# Altium schematic sheet CAN_RPI_Supply.SchDoc
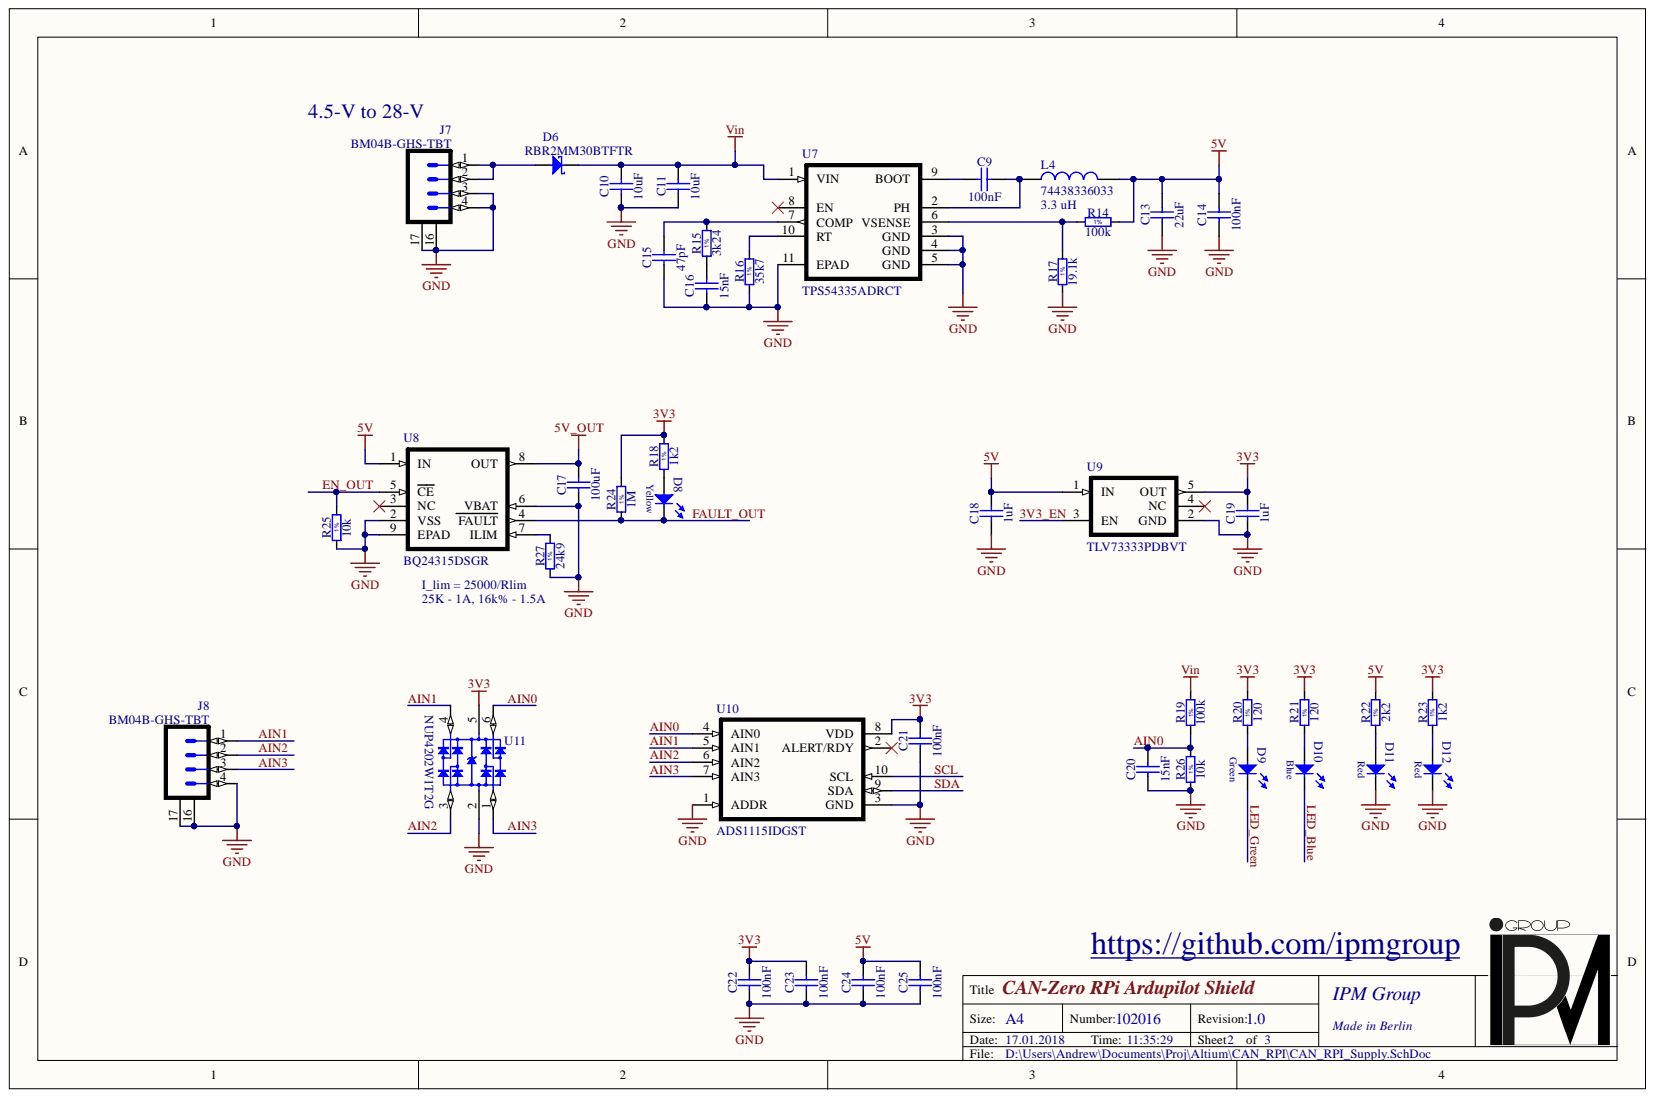
[diagram p0: CAN_RPI_Supply.SchDoc]

## Parts
C9  100nF  [CAPC1005X55N]
C10  10uF  [CAPC3216X190N]
C11  10uF  [CAPC3216X190N]
C13  22uF  [CAPC2012X135N]
C14  100nF  [CAPC1005X55N]
C15  47pF  [CAPC1005X55N]
C16  15nF  [CAPC1005X55N]
C17  100uF  [CAPC3216X180N]
C18  1uF  [CAPC1005X55N]
C19  1uF  [CAPC1005X55N]
C20  15nF  [CAPC1005X55N]
C21  100nF  [CAPC1005X55N]
C22  100nF  [CAPC1005X55N]
C23  100nF  [CAPC1005X55N]
C24  100nF  [CAPC1005X55N]
C25  100nF  [CAPC1005X55N]
D6  RBR2MM30BTFTR  [SOD-123FL]
D8  Color: Yellow  [LEDC1608X80N_RED]  (hidden comment: KPT-1608YC)
D9  KP-1608SGC  [LEDC1608X80N_GREEN]  (value not drawn)
D10  Color: Blue  [LEDC1608X80N_RED]  (hidden comment: KPT-1608QBC-D)
D11  Color: Red  [LEDC1608X80N_RED]  (hidden comment: KP-1608EC)
D12  Color: Red  [LEDC1608X80N_RED]  (hidden comment: KP-1608EC)
J7  BM04B-GHS-TBT  [JST_BM04B]
J8  BM04B-GHS-TBT  [JST_BM04B]
L4  74438336033  [WE-MAPI_3020]
R14  100k  [RESC1005X40N]
R15  3k24  [RESC1005X40N]
R16  35k7  [RESC1005X40N]
R17  19.1k  [RESC1005X40N]
R18  1k2  [RESC1005X40N]
R19  100k  [RESC1005X40N]
R20  120  [RESC1005X40N]
R21  120  [RESC1005X40N]
R22  2k2  [RESC1005X40N]
R23  1k2  [RESC1005X40N]
R24  1M  [RESC1005X40N]
R25  10k  [RESC1005X40N]
R26  10k  [RESC1005X40N]
R27  24k9  [RESC1005X40N]
U7  TPS54335ADRCT  [DRC0010J]
U8  BQ24315DSGR  [DSG8_P9X1P6]
U9  TLV73333PDBVT  [DBV5A]
U10  ADS1115IDGST  [DGS10]
U11  NUP4202W1T2G  [SOIC127P600X175-8N]

## Nets
3V3: C19.2 C21.2 C22.2 C23.2 R18.2 R20.2 R21.2 R23.2 R24.2 U9.5(OUT) U10.8(VDD) U11.5
5V: C13.2 C14.2 C18.2 C24.2 C25.2 L4.2 R14.2 R22.2 U8.1(IN) U9.1(IN)
5V_OUT: C17.2 U8.8(OUT)
GND: C10.1 C11.1 C13.1 C14.1 C15.1 C16.1 C17.1 C18.1 C19.1 C20.1 C21.1 C22.1 C23.1 C24.1 C25.1 D11.1 D12.1 J7.3 J7.4 J7.16 J7.17 J8.4 J8.16 J8.17 R16.1 R17.1 R25.1 R26.1 R27.1 U7.3(GND) U7.4(GND) U7.5(GND) U7.11(EPAD) U8.2(VSS) U8.6(VBAT) U8.9(EPAD) U9.2(GND) U10.1(ADDR) U10.3(GND) U11.2
Vin: C10.2 C11.2 D6.1 R19.2 U7.1(VIN)
3V3_EN: U9.3(EN)
AIN0: C20.2 R19.1 R26.2 U10.4(AIN0) U11.6
AIN1: J8.1 U10.5(AIN1) U11.4
AIN2: J8.2 U10.6(AIN2) U11.3
AIN3: J8.3 U10.7(AIN3) U11.1
EN_OUT: R25.2 U8.5(CE)
FAULT_OUT: D8.1 R24.1 U8.4(FAULT)
LED_Blue: D10.1
LED_Green: D9.1
SCL: U10.10(SCL)
SDA: U10.9(SDA)
NetC9_1: C9.1 U7.9(BOOT)
NetC9_2: C9.2 L4.1 U7.2(PH)
NetC15_2: C15.2 R15.2 U7.7(COMP)
NetC16_2: C16.2 R15.1
NetD6_2: D6.2 J7.1 J7.2
NetD8_2: D8.2 R18.1
NetD9_2: D9.2 R20.1
NetD10_2: D10.2 R21.1
NetD11_2: D11.2 R22.1
NetD12_2: D12.2 R23.1
NetR14_1: R14.1 R17.2 U7.6(VSENSE)
NetR16_2: R16.2 U7.10(RT)
NetR27_2: R27.2 U8.7(ILIM)

Not connected: U7 8(EN); U8 3(NC); U9 4(NC); U10 2(ALERT/RDY)

## Library data of the parts
C9 = CC0402_100NF_50V_10%_X7R: Non-polarised capacitor; Manufacturer: GENERIC; Manufacturer1 Part Number: C1005X7R1H104M050BB; Part Description: SMD Multilayer Chip Ceramic Capacitor; Database Table Name: Capacitors SMD; TC: X7R; Tolerance: ±10%; Voltage: 50V; Case: 0402; Component Kind: Standard; Component Type: Standard; Library Name: Capacitors.DbLib; Manufacturer1 ComponentHeight: 0.55mm; Manufacturer1 Example: TDK; Manufacturer Part Number: CC0402_100NF_50V_10%_X7R; Mounted: Yes; Part Number: CC0402_100NF_50V_10%_X7R; Pin Count: 2; SMD: Yes; Supplier 1: Farnell; Supplier 2: RSComponents; Supplier 3: Digi-Key; Supplier Part Number 1: 2611878; Value: 100nF; Author: CERN DEM JLC
C10 C11 = CC1206_10UF_50V_10%_X5R_TDK_CGA5L3X5R1H106K160AB: Non-polarised capacitor; Manufacturer: MURATA; Manufacturer1 Part Number: GRM31CR6YA106KA12L; Manufacturer Part Number: CC1206_10UF_35V_10%_X5R; Part Description: SMD Multilayer Chip Ceramic Capacitor (Automotive Grade); Database Table Name: Capacitors SMD; TC: X5R; Tolerance: ±10%; Voltage: 35V; Case: 1206; ComponentHeight: 1.9mm; Component Kind: Standard; Component Type: Standard; Library Name: Capacitors.DbLib; Manufacturer1 Example: MURATA; Mounted: Yes; Part Number: CC1206_10UF_35V_10%_X5R; Pin Count: 2; SMD: Yes; Supplier 1: Farnell; Supplier 2: RSComponents; Supplier 3: Digi-Key; Supplier Part Number 1: 1797011; Value: 10uF; Author: CERN DEM BC
C13 = CC0805_22UF_6.3V_20%_X5R: Non-polarised capacitor; Manufacturer: GENERIC; Manufacturer1 Part Number: GRM21BR60J226ME39L; Part Description: SMD Multilayer Chip Ceramic Capacitor; Database Table Name: Capacitors SMD; TC: X5R; Tolerance: ±20%; Voltage: 6.3V; Case: 0805; Component Kind: Standard; Component Type: Standard; Library Name: Capacitors.DbLib; Manufacturer1 ComponentHeight: 1.45mm; Manufacturer1 Example: MURATA; Manufacturer Part Number: CC0805_22UF_6.3V_20%_X5R; Mounted: Yes; Part Number: CC0805_22UF_6.3V_20%_X5R; Pin Count: 2; SMD: Yes; Supplier 1: Farnell; Supplier 2: RSComponents; Supplier 3: Digi-Key; Value: 22uF; Author: CERN DEM JLC
C14 C21-C25 = CC0402_100NF_16V_10%_X7R: Non-polarised capacitor; Manufacturer: GENERIC; Manufacturer1 Part Number: GRM155R71C104KA88D; Part Description: SMD Multilayer Chip Ceramic Capacitor; Database Table Name: Capacitors SMD; TC: X7R; Tolerance: ±10%; Voltage: 16V; Case: 0402; Component Kind: Standard; Component Type: Standard; Library Name: Capacitors.DbLib; Manufacturer1 ComponentHeight: 0.55mm; Manufacturer1 Example: MURATA; Manufacturer Part Number: CC0402_100NF_16V_10%_X7R; Mounted: Yes; Part Number: CC0402_100NF_16V_10%_X7R; Pin Count: 2; SMD: Yes; Supplier 1: Farnell; Supplier 2: RSComponents; Supplier 3: Digi-Key; Value: 100nF; Author: CERN DEM JLC
C15 = CC0402_47PF_50V_5%_C0G: Non-polarised capacitor; Manufacturer: GENERIC; Manufacturer1 Part Number: MC0402N470J500CT; Part Description: SMD Multilayer Chip Ceramic Capacitor; Database Table Name: Capacitors SMD; TC: C0G/NP0; Tolerance: ±5%; Voltage: 50V; Case: 0402; Component Kind: Standard; Component Type: Standard; Library Name: Capacitors.DbLib; Manufacturer1 ComponentHeight: 0.55mm; Manufacturer1 Example: MULTICOPM; Manufacturer Part Number: CC0402_47PF_50V_5%_C0G; Mounted: Yes; Part Number: CC0402_47PF_50V_5%_C0G; Pin Count: 2; SMD: Yes; Supplier 1: Farnell; Supplier 2: RSComponents; Supplier 3: Digi-Key; Supplier Part Number 1: 1758959RL; Value: 47pF; Author: CERN DEM JLC
C16 C20 = CC0402_15NF_16V_10%_X7R: Non-polarised capacitor; Manufacturer: GENERIC; Manufacturer1 Part Number: MC0402B153K160CT; Part Description: SMD Multilayer Chip Ceramic Capacitor; Database Table Name: Capacitors SMD; TC: X7R; Tolerance: ±10%; Voltage: 16V; Case: 0402; Component Kind: Standard; Component Type: Standard; Library Name: Capacitors.DbLib; Manufacturer1 ComponentHeight: 0.55mm; Manufacturer1 Example: MULTICOMP; Manufacturer Part Number: CC0402_15NF_16V_10%_X7R; Mounted: Yes; Part Number: CC0402_15NF_16V_10%_X7R; Pin Count: 2; SMD: Yes; Supplier 1: Farnell; Supplier 2: RSComponents; Supplier 3: Digi-Key; Supplier Part Number 1: 1758887RL; Value: 15nF; Author: CERN DEM JLC
C17 = CC1206_100UF_6.3V_20%_X5R: Non-polarised capacitor; Manufacturer: GENERIC; Manufacturer1 Part Number: GRM31CR60J107ME39K; Part Description: SMD Multilayer Chip Ceramic Capacitor; Database Table Name: Capacitors SMD; TC: X5R; Tolerance: ±10%; Voltage: 6.3V; Case: 1206; Component Kind: Standard; Component Type: Standard; Library Name: Capacitors.DbLib; Manufacturer1 ComponentHeight: 1.8mm; Manufacturer1 Example: MURATA; Manufacturer Part Number: CC1206_100UF_6.3V_20%_X5R; Mounted: Yes; Part Number: CC1206_100UF_6.3V_20%_X5R; Pin Count: 2; SMD: Yes; Supplier 1: Farnell; Supplier 2: RSComponents; Supplier 3: Digi-Key; Supplier Part Number 1: 2611951; Value: 100uF; Author: CERN DEM JLC
C18 C19 = CC0402_1UF_16V_10%_X5R: Non-polarised capacitor; Manufacturer: GENERIC; Manufacturer1 Part Number: GRM155C80J105KE15D; Manufacturer Part Number: CC0402_1UF_6.3V_10%_X5R; Part Description: SMD Multilayer Chip Ceramic Capacitor; Database Table Name: Capacitors SMD; TC: X5R; Tolerance: ±10%; Voltage: 6.3V; Case: 0402; Component Kind: Standard; Component Type: Standard; Library Name: Capacitors.DbLib; Manufacturer1 ComponentHeight: 0.55mm; Manufacturer1 Example: MURATA; Mounted: Yes; Part Number: CC0402_1UF_6.3V_10%_X5R; Pin Count: 2; SMD: Yes; Supplier 1: Farnell; Supplier 2: RSComponents; Supplier 3: Digi-Key; Value: 1uF; Author: CERN DEM JLC
D6 = RBR2MM30BTFTR: Schottky Diode; Manufacturer: ROHM; Part Description: Power Schottky rectifier; RatedCurrent: 2A; Voltage: 30V; Case: SOD-123FL; ComponentHeight: 2.65mm; Component Kind: Standard; Component Type: Standard; Library Name: My_PCB.SchLib; Manufacturer1 Example: ROHM; Manufacturer1 Part Number: RBR2MM30BTFTR; Manufacturer Part Number: RBR2MM30BTFTR; Mounted: Yes; PackageDescription: SOD-123FL Molded Diode, Body 3.5x1.6mm, IPC Medium Density; Part Number: RBR2MM30BTFTR; Pin Count: 2; SMD: Yes; Supplier 1: Farnell; Supplier 2: RSComponents; Supplier 3: Digi-Key; Supplier Part Number 1: 2581585; Author: CERN DEM JLC
D8-D10 = (no symbol name): LED Red 1C 2A; Manufacturer: KINGBRIGHT; Part Description: Low current chip LED SML-311 Serie; Case: 0603; ComponentHeight: 0.8mm; Component Kind: Standard; Component Type: Standard; Manufacturer1 Example: KINGBRIGHT; Mounted: Yes; Pin Count: 2; SMD: Yes; Supplier 1: Farnell; Supplier 2: RSComponents; Supplier 3: Digi-Key; Author: CERN DEM JLC
  D8: Manufacturer1 Part Number: KPT-1608YC; Manufacturer Part Number: KPT-1608YC; PackageDescription: Chip Yellow LED, 2-Leads, Body 1.6x0.8mm, IPC Medium Density; Part Number: KPT-1608YC; Supplier Part Number 1: 2099227
  D9: Color: Red; Manufacturer1 Part Number: KP-1608SGC; Manufacturer Part Number: KP-1608SGC; PackageDescription: Chip Green LED, 2-Leads, Body 1.6x0.8mm, IPC Medium Density; Part Number: KP-1608SGC; Supplier Part Number 1: 8529833
  D10: Library Name: CAN_RPI.SCHLIB; Manufacturer1 Part Number: KPT-1608QBC-D; Manufacturer Part Number: KPT-1608QBC-D; PackageDescription: Chip Blue LED, 2-Leads, Body 1.6x0.8mm, IPC Medium Density; Part Number: KPT-1608QBC-D; Supplier Part Number 1: 2217978
  D8 D9: Library Name: USBtoCAN.SCHLIB
D11 D12 = LED_ROHM_SML-311UTT86K: LED Red 1C 2A; Manufacturer: KINGBRIGHT; Part Description: Low current chip LED SML-311 Serie; Part Number: KP-1608SURC; Database Table Name: LEDs & Displays; Case: 0603; ComponentHeight: 0.8mm; Component Kind: Standard; Component Type: Standard; Library Name: ICs And Semiconductors.DbLib; Manufacturer1 Example: KINGBRIGHT; Manufacturer1 Part Number: KP-1608EC; Manufacturer Part Number: KP-1608EC; Mounted: Yes; PackageDescription: Chip Red LED, 2-Leads, Body 1.6x0.8mm, IPC Medium Density; Pin Count: 2; SMD: Yes; Supplier 1: Farnell; Supplier 2: RSComponents; Supplier 3: Digi-Key; Supplier Part Number 1: 2463988; Author: CERN DEM JLC
J7 J8 = JST_GH_BM04: Connector 4 Male; Manufacturer: JST; Part Description: 4 Contacts - Pitch 1.25mm; ComponentHeight: 4.05.mm; Component Kind: Standard; Component Type: Standard; Family: Header 4; Library Name: My_PCB.SchLib; Manufacturer1 Example: JST; Manufacturer1 Part Number: BM04B-GHS-TBT; Manufacturer Part Number: BM04B-GHS-TBT; Mounted: Yes; Part Number: BM04B-GHS-TBT; Pin Count: 4; SMD: Yes; Supplier 1: Farnell; Supplier 2: RSComponents; Supplier 3: Digi-Key; Supplier Part Number 2: 752-1769; Author: CERN DEM JLC
L4 = 74438336033: WE-MAPI SMD Shielded Power Inductor, size 3020, 3.3 uH; Manufacturer: WURTH ELEKTRONIK; Manufacturer1 Part Number: SPM3020T-3R3M-LR; Part Description: WE-MAPI SMD Power Inductor; RatedCurrent: 2.2 A; Value: 3.3 uH; Case: 3020; Component Kind: Standard; Component Type: Standard; Library Name: ALTIUM-WE-MAPI-rev16b.IntLib; Manufacturer1 Example: TDK; Manufacturer Part Number: 74438336033; Mounted: Yes; Part Number: 74438336033; Pin Count: 2; SMD: Yes; Supplier 1: Farnell; Supplier 2: RSComponents; Supplier 3: Digi-Key; Supplier Part Number 1: 2448582, 2564010; Supplier Part Number 2: 863-0817
R14 R19 = R0402_100K_1%_0.0625W_100PPM: Resistor - 1%; Manufacturer: GENERIC; Manufacturer1 Part Number: MC00625W04021100K; Part Description: General Purpose Thick Film Chip Resistor; Database Table Name: Resistors SMD; TC: ±100ppm/°C; Case: 0402; Component Kind: Standard; Component Type: Standard; Library Name: Resistors.DbLib; Manufacturer1 ComponentHeight: 0.4mm; Manufacturer1 Example: MULTICOPM; Manufacturer Part Number: R0402_100K_1%_0.0625W_100PPM; Mounted: Yes; Part Number: R0402_100K_1%_0.0625W_100PPM; Pin Count: 2; Power: 0.0625W; SMD: Yes; Supplier 1: Farnell; Supplier 2: RSComponents; Supplier 3: Digi-Key; Supplier Part Number 1: 1358096; Tolerance: ±1%; Value: 100k; Author: CERN DEM JLC
R15 = R0402_3K3_1%_0.0625W_100PPM: Resistor - 1%; Manufacturer: GENERIC; Manufacturer1 Part Number: ERJ2RKF3241X; Manufacturer Part Number: R0402_3K24_1%_0.0625W_100PPM; Part Description: General Purpose Thick Film Chip Resistor; Database Table Name: Resistors SMD; TC: ±100ppm/°C; Case: 0402; Component Kind: Standard; Component Type: Standard; Library Name: Resistors.DbLib; Manufacturer1 ComponentHeight: 0.4mm; Manufacturer1 Example: PANASONIC; Mounted: Yes; Part Number: R0402_3K24_1%_0.0625W_100PPM; Pin Count: 2; Power: 0.0625W; SMD: Yes; Supplier 1: Farnell; Supplier 2: RSComponents; Supplier 3: Digi-Key; Supplier Part Number 1: 2302689; Tolerance: ±1%; Value: 3k24; Author: CERN DEM JLC
R16 = R0402_357K_1%_0.0625W_100PPM: Resistor - 1%; Manufacturer: GENERIC; Manufacturer1 Part Number: ERJ2RKF3572X; Manufacturer Part Number: R0402_35K7_1%_0.0625W_100PPM; Part Description: General Purpose Thick Film Chip Resistor; Database Table Name: Resistors SMD; TC: ±100ppm/°C; Case: 0402; Component Kind: Standard; Component Type: Standard; Library Name: Resistors.DbLib; Manufacturer1 ComponentHeight: 0.4mm; Manufacturer1 Example: PANASONIC; Mounted: Yes; Part Number: R0402_35K7_1%_0.0625W_100PPM; Pin Count: 2; Power: 0.0625W; SMD: Yes; Supplier 1: Farnell; Supplier 2: RSComponents; Supplier 3: Digi-Key; Supplier Part Number 1: 2302793; Tolerance: ±1%; Value: 35k7; Author: CERN DEM BC
R17 = R0402_20K_1%_0.0625W_100PPM: Resistor - 1%; Manufacturer: GENERIC; Manufacturer1 Part Number: ERJ2RKF1912X; Manufacturer Part Number: R0402_19.1K_1%_0.0625W_100PPM; Part Description: General Purpose Thick Film Chip Resistor; Database Table Name: Resistors SMD; TC: ±100ppm/°C; Case: 0402; Component Kind: Standard; Component Type: Standard; Library Name: Resistors.DbLib; Manufacturer1 ComponentHeight: 0.4mm; Manufacturer1 Example: PANASONIC; Mounted: Yes; Part Number: R0402_19.1K_1%_0.0625W_100PPM; Pin Count: 2; Power: 0.0625W; SMD: Yes; Supplier 1: Farnell; Supplier 2: RSComponents; Supplier 3: Digi-Key; Supplier Part Number 1: 2302766; Tolerance: ±1%; Value: 19.1k; Author: CERN DEM JLC
R18 R23 = R0402_1K2_1%_0.0625W_100PPM: Resistor - 1%; Manufacturer: GENERIC; Manufacturer1 Part Number: 232270671202L; Part Description: General Purpose Thick Film Chip Resistor; Database Table Name: Resistors SMD; TC: ±100ppm/°C; Case: 0402; Component Kind: Standard; Component Type: Standard; Library Name: Resistors.DbLib; Manufacturer1 ComponentHeight: 0.4mm; Manufacturer1 Example: YAGEO PHYCOMP; Manufacturer Part Number: R0402_1K2_1%_0.0625W_100PPM; Mounted: Yes; Part Number: R0402_1K2_1%_0.0625W_100PPM; Pin Count: 2; Power: 0.0625W; SMD: Yes; Supplier 1: Farnell; Supplier 2: RSComponents; Supplier 3: Digi-Key; Tolerance: ±1%; Value: 1k2; Author: CERN DEM JLC
R20 R21 = R0402_120R_1%_0.0625W_100PPM: Resistor - 1%; Manufacturer: GENERIC; Manufacturer1 Part Number: 232270671201L; Part Description: General Purpose Thick Film Chip Resistor; Database Table Name: Resistors SMD; TC: ±100ppm/°C; Case: 0402; Component Kind: Standard; Component Type: Standard; Library Name: Resistors.DbLib; Manufacturer1 ComponentHeight: 0.4mm; Manufacturer1 Example: YAGEO PHYCOMP; Manufacturer Part Number: R0402_120R_1%_0.0625W_100PPM; Mounted: Yes; Part Number: R0402_120R_1%_0.0625W_100PPM; Pin Count: 2; Power: 0.0625W; SMD: Yes; Supplier 1: Farnell; Supplier 2: RSComponents; Supplier 3: Digi-Key; Tolerance: ±1%; Value: 120; Author: CERN DEM JLC
R22 = R0402_2K2_1%_0.0625W_100PPM: Resistor - 1%; Manufacturer: GENERIC; Manufacturer1 Part Number: 232270672202L; Part Description: General Purpose Thick Film Chip Resistor; Database Table Name: Resistors SMD; TC: ±100ppm/°C; Case: 0402; Component Kind: Standard; Component Type: Standard; Library Name: Resistors.DbLib; Manufacturer1 ComponentHeight: 0.4mm; Manufacturer1 Example: YAGEO PHYCOMP; Manufacturer Part Number: R0402_2K2_1%_0.0625W_100PPM; Mounted: Yes; Part Number: R0402_2K2_1%_0.0625W_100PPM; Pin Count: 2; Power: 0.0625W; SMD: Yes; Supplier 1: Farnell; Supplier 2: RSComponents; Supplier 3: Digi-Key; Tolerance: ±1%; Value: 2k2; Author: CERN DEM JLC
R24 = R0402_1M_1%_0.0625W_100PPM: Resistor - 1%; Manufacturer: GENERIC; Manufacturer1 Part Number: MC00625W040211M; Part Description: General Purpose Thick Film Chip Resistor; Database Table Name: Resistors SMD; TC: ±100ppm/°C; Case: 0402; Component Kind: Standard; Component Type: Standard; Library Name: Resistors.DbLib; Manufacturer1 ComponentHeight: 0.4mm; Manufacturer1 Example: MULTICOPM; Manufacturer Part Number: R0402_1M_1%_0.0625W_100PPM; Mounted: Yes; Part Number: R0402_1M_1%_0.0625W_100PPM; Pin Count: 2; Power: 0.0625W; SMD: Yes; Supplier 1: Farnell; Supplier 2: RSComponents; Supplier 3: Digi-Key; Supplier Part Number 1: 1358123; Tolerance: ±1%; Value: 1M; Author: CERN DEM JLC
R25 R26 = R0402_10K_1%_0.0625W_100PPM: Resistor - 1%; Manufacturer: GENERIC; Manufacturer1 Part Number: MC00625W0402110K; Part Description: General Purpose Thick Film Chip Resistor; Database Table Name: Resistors SMD; TC: ±100ppm/°C; Case: 0402; Component Kind: Standard; Component Type: Standard; Library Name: Resistors.DbLib; Manufacturer1 ComponentHeight: 0.4mm; Manufacturer1 Example: MULTICOPM; Manufacturer Part Number: R0402_10K_1%_0.0625W_100PPM; Mounted: Yes; Part Number: R0402_10K_1%_0.0625W_100PPM; Pin Count: 2; Power: 0.0625W; SMD: Yes; Supplier 1: Farnell; Supplier 2: RSComponents; Supplier 3: Digi-Key; Supplier Part Number 1: 1358069; Tolerance: ±1%; Value: 10k; Author: CERN DEM JLC
R27 = R0402_24K_1%_0.0625W_100PPM: Resistor - 1%; Manufacturer: GENERIC; Manufacturer1 Part Number: MC00625W0402124K9; Manufacturer Part Number: R0402_24K9_1%_0.0625W_100PPM; Part Description: General Purpose Thick Film Chip Resistor; Database Table Name: Resistors SMD; TC: ±100ppm/°C; Case: 0402; Component Kind: Standard; Component Type: Standard; Library Name: Resistors.DbLib; Manufacturer1 ComponentHeight: 0.4mm; Manufacturer1 Example: MULTICOPM; Mounted: Yes; Part Number: R0402_24K9_1%_0.0625W_100PPM; Pin Count: 2; Power: 0.0625W; SMD: Yes; Supplier 1: Farnell; Supplier 2: RSComponents; Supplier 3: Digi-Key; Supplier Part Number 1: 1803690; Tolerance: ±1%; Value: 24k9; Author: CERN DEM JLC
U7 = TPS54335ADRCT: 4.5-V to 28-V Input, 3-A Output,  Synchronous  Step-Down  DC-DC  Converter; Manufacturer: Texas Instruments; Case: VSOP; Component Kind: Standard; Component Type: Standard; Library Name: My_PCB.SchLib; Manufacturer1 Example: Texas Instruments; Manufacturer1 Part Number: TPS54335ADRCT; Manufacturer Part Number: TPS54335ADRCT; Mounted: Yes; Part Description: 4.5-V to 28-V Input, 3-A Output,  Synchronous  Step-Down  DC-DC  Converter; Part Number: TPS54335ADRCT; Pin Count: 10; SMD: Yes; Supplier 1: Farnell; Supplier 2: RSComponents; Supplier 3: Digi-Key; Supplier Part Number 1: 2392476
U8 = BQ24315DSGT: OVERVOLTAGE  AND  OVERCURRENT  PROTECTION  IC  AND  Li+  CHARGER  FRONT-END  PROTECTION  IC; Manufacturer: Texas Instruments; Case: WSOP; Component Kind: Standard; Component Type: Standard; Library Name: My_PCB.SchLib; Manufacturer1 Example: Texas Instruments; Manufacturer1 Part Number: BQ24315DSGR; Manufacturer Part Number: BQ24315DSGR; Mounted: Yes; Part Description: OVERVOLTAGE  AND  OVERCURRENT  PROTECTION  IC  AND  Li+  CHARGER  FRONT-END  PROTECTION  IC; Part Number: BQ24315DSGR; Pin Count: 8; SMD: Yes; Supplier 1: Farnell; Supplier 2: RSComponents; Supplier 3: Digi-Key; Supplier Part Number 1: 2492095; Supplier Part Number 2: 827-9318
U9 = TLV73333PDBVT: LDO 3.3V Capacitor-Free,  300-mA, Foldback  Current  Limit; Manufacturer: Texas Instruments; Case: SOT-23; Component Kind: Standard; Component Type: Standard; Library Name: My_PCB.SchLib; Manufacturer1 Example: Texas Instruments; Manufacturer1 Part Number: TLV73333PDBVT; Manufacturer Part Number: TLV73333PDBVT; Mounted: Yes; Part Description: LDO 3.3V Capacitor-Free,  300-mA, Foldback  Current  Limit; Part Number: TLV73333PDBVT; Pin Count: 5; SMD: Yes; Supplier 1: Farnell; Supplier 2: RSComponents; Supplier 3: Digi-Key; Supplier Part Number 1: 2455145
U10 = ADS1115IDGST: Ultra-Small,  Low-Power,  16-Bit  Analog-to-Digital  Converter  with  Internal  Reference; Manufacturer: Texas Instruments; Case: MSOP; Component Kind: Standard; Component Type: Standard; Library Name: My_PCB.SchLib; Manufacturer1 Example: Texas Instruments; Manufacturer1 Part Number: ADS1115IDGST; Manufacturer Part Number: ADS1115IDGST; Mounted: Yes; Part Description: Ultra-Small,  Low-Power,  16-Bit  Analog-to-Digital  Converter  with  Internal  Reference; Part Number: ADS1115IDGST; Pin Count: 10; SMD: Yes; Supplier 1: Farnell; Supplier 2: RSComponents; Supplier 3: Digi-Key; Supplier Part Number 1: 1762981
U11 = (no symbol name): Low Capacitance Surface Mount TVS for High Speed Data Interfaces; Manufacturer: ON SEMICONDUCTOR; Part Description: ESD Low Capacitance Surface Mount TVS for High Speed Data Interfaces; Power: 500W; Voltage: 5V; Case: SC-88; ComponentHeight: 1.75mm; Component Kind: Standard; Component Type: Standard; Library Name: My_PCB.SchLib; Manufacturer1 Example: ON SEMICONDUCTOR; Manufacturer1 Part Number: NUP4202W1T2G; Manufacturer Part Number: NUP4202W1T2G; Mounted: Yes; PackageDescription: TSOP, 6-Leads, Body 2,00x1,25mm, Pitch 0,65mm,; Part Number: NUP4202W1T2G; Pin Count: 6; SMD: Yes; Supplier 1: Farnell; Supplier 2: RSComponents; Supplier 3: Digi-Key; Supplier Part Number 1: 2533281; Author: CERN DEM JLC
